# Altium schematic sheet SAA1099.SchDoc
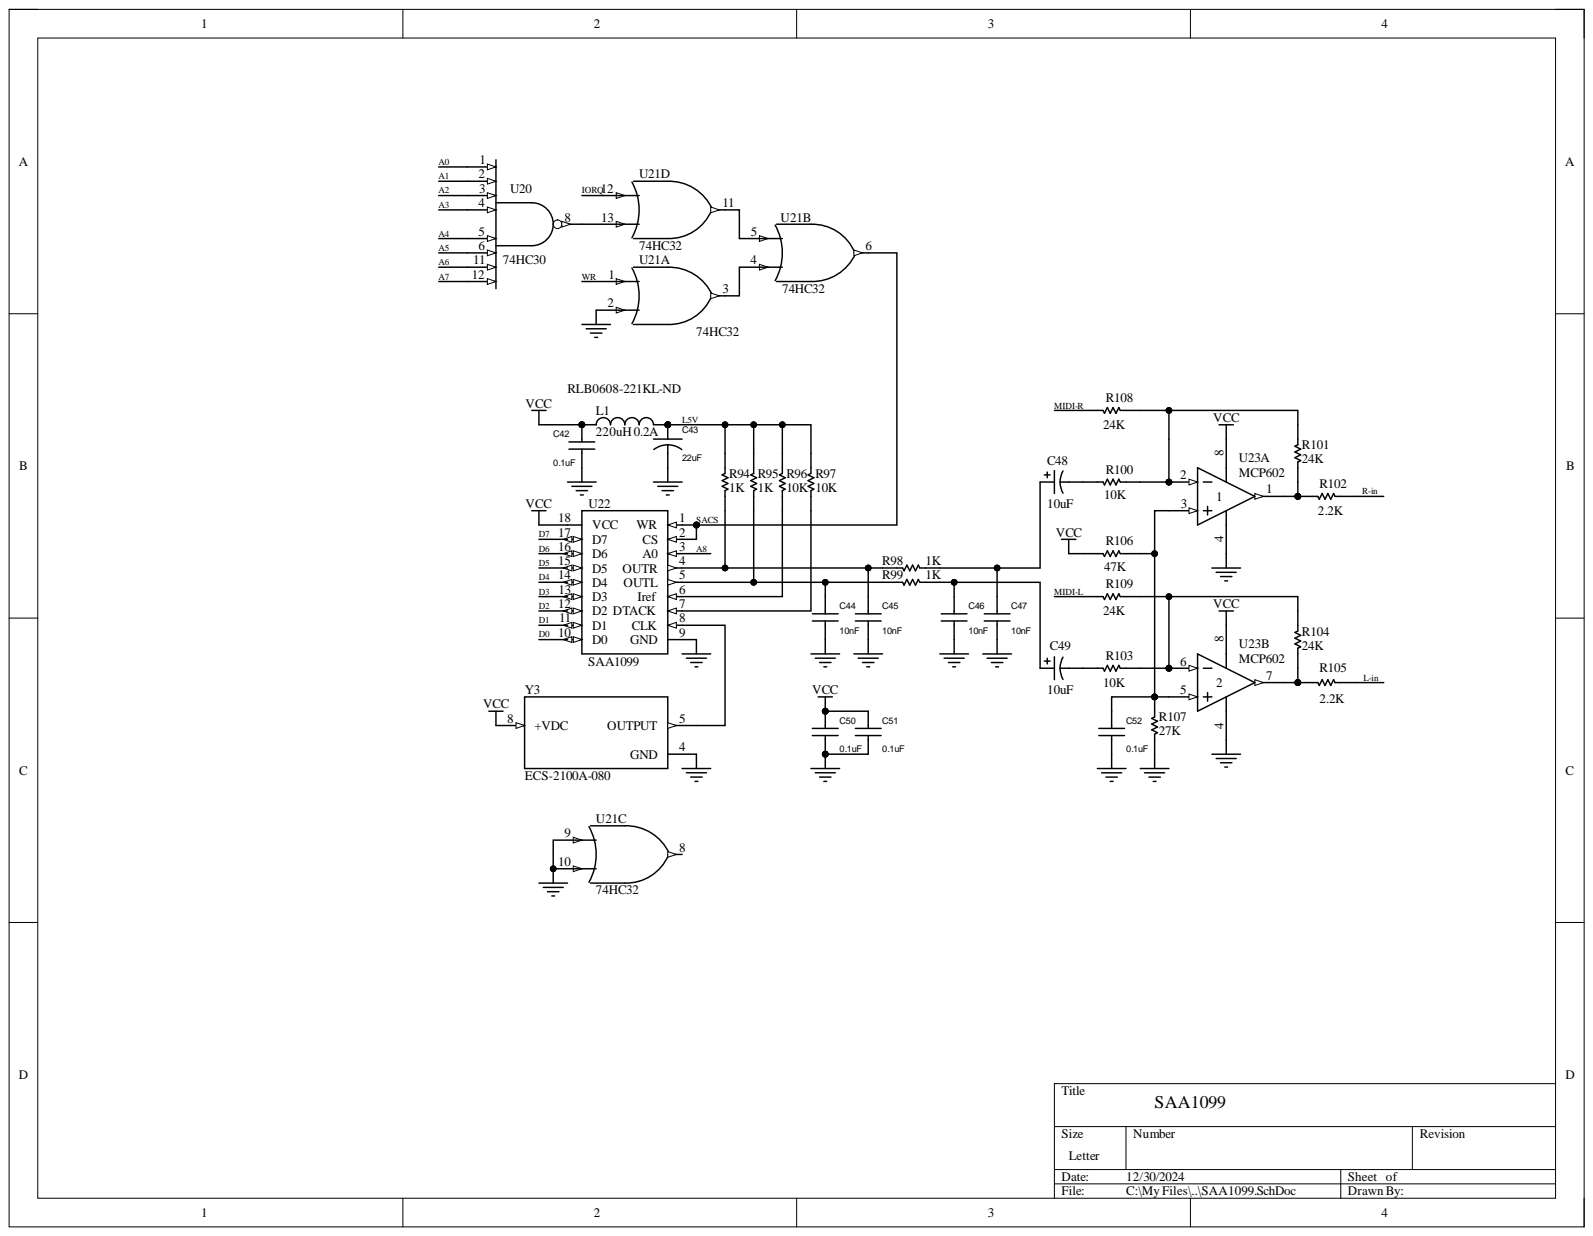
[diagram p0: SAA1099.SchDoc]

## Parts
C42  0.1uF  [RAD/.200X.100/LS.100/.031]
C43  22uF  [CYL/D.150/LS.100/.031]
C44  10nF  [RAD/.200X.100/LS.100/.031]
C45  10nF  [RAD/.200X.100/LS.100/.031]
C46  10nF  [RAD/.200X.100/LS.100/.031]
C47  10nF  [RAD/.200X.100/LS.100/.031]
C48  10uF  [CYL/D.150/LS.100/.031]
C49  10uF  [CYL/D.150/LS.100/.031]
C50  0.1uF  [RAD/.200X.100/LS.100/.031]
C51  0.1uF  [RAD/.200X.100/LS.100/.031]
C52  0.1uF  [RAD/.200X.100/LS.100/.031]
L1  RLB0608-221KL-ND  value 220uH 0.2A  [CYL/D.150/LS.100/.031]
R94  1K  [AX/.350X.100/.034]
R95  1K  [AX/.350X.100/.034]
R96  10K  [AX/.350X.100/.034]
R97  10K  [AX/.350X.100/.034]
R98  1K  [AX/.350X.100/.034]
R99  1K  [AX/.350X.100/.034]
R100  10K  [AX/.350X.100/.034]
R101  24K  [AX/.350X.100/.034]
R102  2.2K  [AX/.350X.100/.034]
R103  10K  [AX/.350X.100/.034]
R104  24K  [AX/.350X.100/.034]
R105  2.2K  [AX/.350X.100/.034]
R106  47K  [AX/.350X.100/.034]
R107  27K  [AX/.350X.100/.034]
R108  24K  [AX/.350X.100/.034]
R109  24K  [AX/.350X.100/.034]
U20  74HC30  [DIP.100/14/W.300/L.700]
U21  74HC32  [DIP.100/14/W.300/L.700]  (parts A,B,C,D)
U22  SAA1099  [DIP.100/18/W.300/L.875]
U23  MCP602  [D008_L]  (parts A,B)
Y3  ECS-2100A-080  [OSC_ECS-2100A-080]

## Nets
GND: C42.2 C43.2 C44.2 C45.2 C46.2 C47.2 C50.2 C51.2 C52.2 R107.2 U20.7 U21.2 U21.7 U21.9 U21.10 U22.9(GND) U23.4 Y3.4
VCC: C42.1 C50.1 C51.1 L1.1 R106.2 U20.14 U21.14 U22.18(VCC) U23.8 Y3.8
A0: U20.1
A1: U20.2
A2: U20.3
A3: U20.4
A4: U20.5
A5: U20.6
A6: U20.11
A7: U20.12
A8: U22.3(A0)
D0: U22.10(D0)
D1: U22.11(D1)
D2: U22.12(D2)
D3: U22.13(D3)
D4: U22.14(D4)
D5: U22.15(D5)
D6: U22.16(D6)
D7: U22.17(D7)
IORQ: U21.12
L5V: C43.1 L1.2 R94.2 R95.2 R96.2 R97.2
L-in: R105.1
MIDI-L: R109.2
MIDI-R: R108.2
R-in: R102.1
SACS: U21.6 U22.1(WR) U22.2(CS)
WR: U21.1
NetC44_1: C44.1 R95.1 R99.2 U22.5(OUTL)
NetC45_1: C45.1 R94.1 R98.2 U22.4(OUTR)
NetC46_1: C46.1 C49.1 R99.1
NetC47_1: C47.1 C48.1 R98.1
NetC48_2: C48.2 R100.2
NetC49_2: C49.2 R103.2
NetC52_1: C52.1 R106.1 R107.1 U23.3 U23.5
NetR96_1: R96.1 U22.6(Iref)
NetR97_1: R97.1 U22.7(DTACK)
NetR100_1: R100.1 R101.1 R108.1 U23.2
NetR101_2: R101.2 R102.2 U23.1
NetR103_1: R103.1 R104.1 R109.1 U23.6
NetR104_2: R104.2 R105.2 U23.7
NetU20_8: U20.8 U21.13
NetU21_3: U21.3 U21.4
NetU21_5: U21.5 U21.11
NetU22_8: U22.8(CLK) Y3.5

Not connected: U21 8

## Library data of the parts
C42 C50-C52 = CAP NP: COMPFIXED: NO; COMPGROUP: 0; COMPHEIGHT: 0.000; COMPLOC: [3150.317,4300.317]; COMPROT: 180; COMPSIDE: TOP; COMPKEY: NO; COMPLOCKED: NO; Component Kind: Standard; DECOUPLER: NO; MEMORY: NO
C43 = C: COMPFIXED: NO; COMPGROUP: 0; COMPHEIGHT: 0.000; COMPLOC: [875.000,4250.000]; COMPROT: 90; COMPSIDE: TOP; COMPKEY: NO; COMPLOCKED: NO; Component Kind: Standard; DECOUPLER: NO; MEMORY: NO
C44-C47 = CAP NP: COMPFIXED: NO; COMPGROUP: 0; COMPHEIGHT: 0.000; COMPLOC: [350.000,1925.000]; COMPROT: 180; COMPSIDE: TOP; COMPKEY: NO; COMPLOCKED: NO; Component Kind: Standard; DECOUPLER: NO; MEMORY: NO
C48 C49 = Cap Pol1: Polarized Capacitor (Radial); Component Kind: Standard; LatestRevisionDate: 17-Jul-2002; LatestRevisionNote: Re-released for DXP Platform.; Library Name: Miscellaneous Devices.IntLib; PackageReference: RB7.6-15; Published: 8-Jun-2000; Signal Integrity: Cap Pol; Simulation: CAP; Value: 10uF; Publisher: Altium Limited
L1 = Inductor: Code_IEC: 1005; Code_JEITA: 0402; Component Kind: Standard; Description: Inductor; LatestRevisionDate: 29-May-2009; LatestRevisionNote: IPC-7351 Footprint Added.; Library Name: Miscellaneous Devices.IntLib; PackageDescription: Chip Inductor; PackageReference: 0402-A; Published: 8-Jun-2000; Signal Integrity: Inductor; Simulation: INDUCTOR; Publisher: Altium Limited
R94-R109 = Res1: Resistor; Component Kind: Standard; LatestRevisionDate: 17-Jul-2002; LatestRevisionNote: Re-released for DXP Platform.; Library Name: Miscellaneous Devices.IntLib; PackageReference: AXIAL-0.3; Published: 8-Jun-2000; Signal Integrity: Res; Simulation: RESISTOR; Publisher: Altium Limited
  R94 R95 R98 R99: Value: 1K
  R96 R97 R100 R103: Value: 10K
  R101 R104 R108 R109: Value: 24K
  R102 R105: Value: 2.2K
  R106: Value: 47K
  R107: Value: 27K
U20 = SN74ALS30AN: 8-Input Positive-NAND Gate; Note: Pins 9, 10  and 13 are not connected and not drawn; Component Kind: Standard; ComponentLink1Description: Manufacturer Link; ComponentLink1URL: http://www.ti.com/; ComponentLink2Description: Datasheet; ComponentLink2URL: http://www-s.ti.com/sc/ds/sn74als30a.pdf; ComponentLink3Description: Packaging Specification; ComponentLink3URL: http://focus.ti.com/lit/ml/mpdi002c/mpdi002c.pdf; DatasheetVersion: Dec-1994; LatestRevisionDate: 04-Mar-2005; LatestRevisionNote: Stylized 3D Model Added.; Library Name: TI Logic Gate 1.IntLib; PackageDescription: DIP; 14 Leads; Row Spacing 7.62 mm; Pitch 2.54 mm; PackageReference: N014; PackageVersion: Oct-1995; PCB3D: N014; Published: 8-Jun-2000; Signal Integrity: SN74ALS30AN; Publisher: Altium Limited
U21 = 74HC32: IO_LEVEL: 0; PSpiceTemplate: X^@REFDES %A %B %Y %VCC %GND @MODEL PARAMS:\n+ IO_LEVEL=@IO_LEVEL MNTYMXDLY=@MNTYMXDLY; Component Kind: Standard; MNTYMXDLY: 0; Simulation: 74HC32; Library Name: Retro Computer.SchLib
U22 = SAA1099: IO_LEVEL: 0; PSpiceTemplate: X^@REFDES %DIR %G\ %A1 %A2 %A3 %A4 %A5 %A6 %A7 %A8 %B1 %B2 %B3 %B4 %B5 %B6 %B7 %B8 %VCC %GND @MODEL PARAMS:\n+ IO_LEVEL=@IO_LEVEL MNTYMXDLY=@MNTYMXDLY; Component Kind: Standard; MNTYMXDLY: 0; Simulation: 74HC245; Library Name: Retro Computer.SchLib
U23 = LM358DR: Dual Operational Amplifier; Code_IPC: SOIC127P600-8; Component Kind: Standard; ComponentLink1Description: Manufacturer Link; ComponentLink1URL: http://www.ti.com/; ComponentLink2Description: Datasheet; ComponentLink2URL: http://www-s.ti.com/sc/ds/lm358.pdf; DatasheetVersion: Aug-1988; LatestRevisionDate: 13-Apr-2006; LatestRevisionNote: IPC-7351 Footprint Added.; Library Name: TI Operational Amplifier.IntLib; PackageDescription: 8-Pin Small Outline Integrated Circuit 1.27 mm Pitch; PackageReference: D008; PackageVersion: Jan-1998; PCB3D: D008; Published: 15-Apr-2002; Publisher: Altium Limited
Y3 = ECS-2100A-080: TL Oscillator 8-DIP, 4 Leads; Availability: Unavailable; Component Kind: Standard; MP: ECS-2100A-080; Package: None; Price: None; Library Name: Retro Computer.SchLib
